# Altium schematic sheet VoltTap.SchDoc(VoltTap)
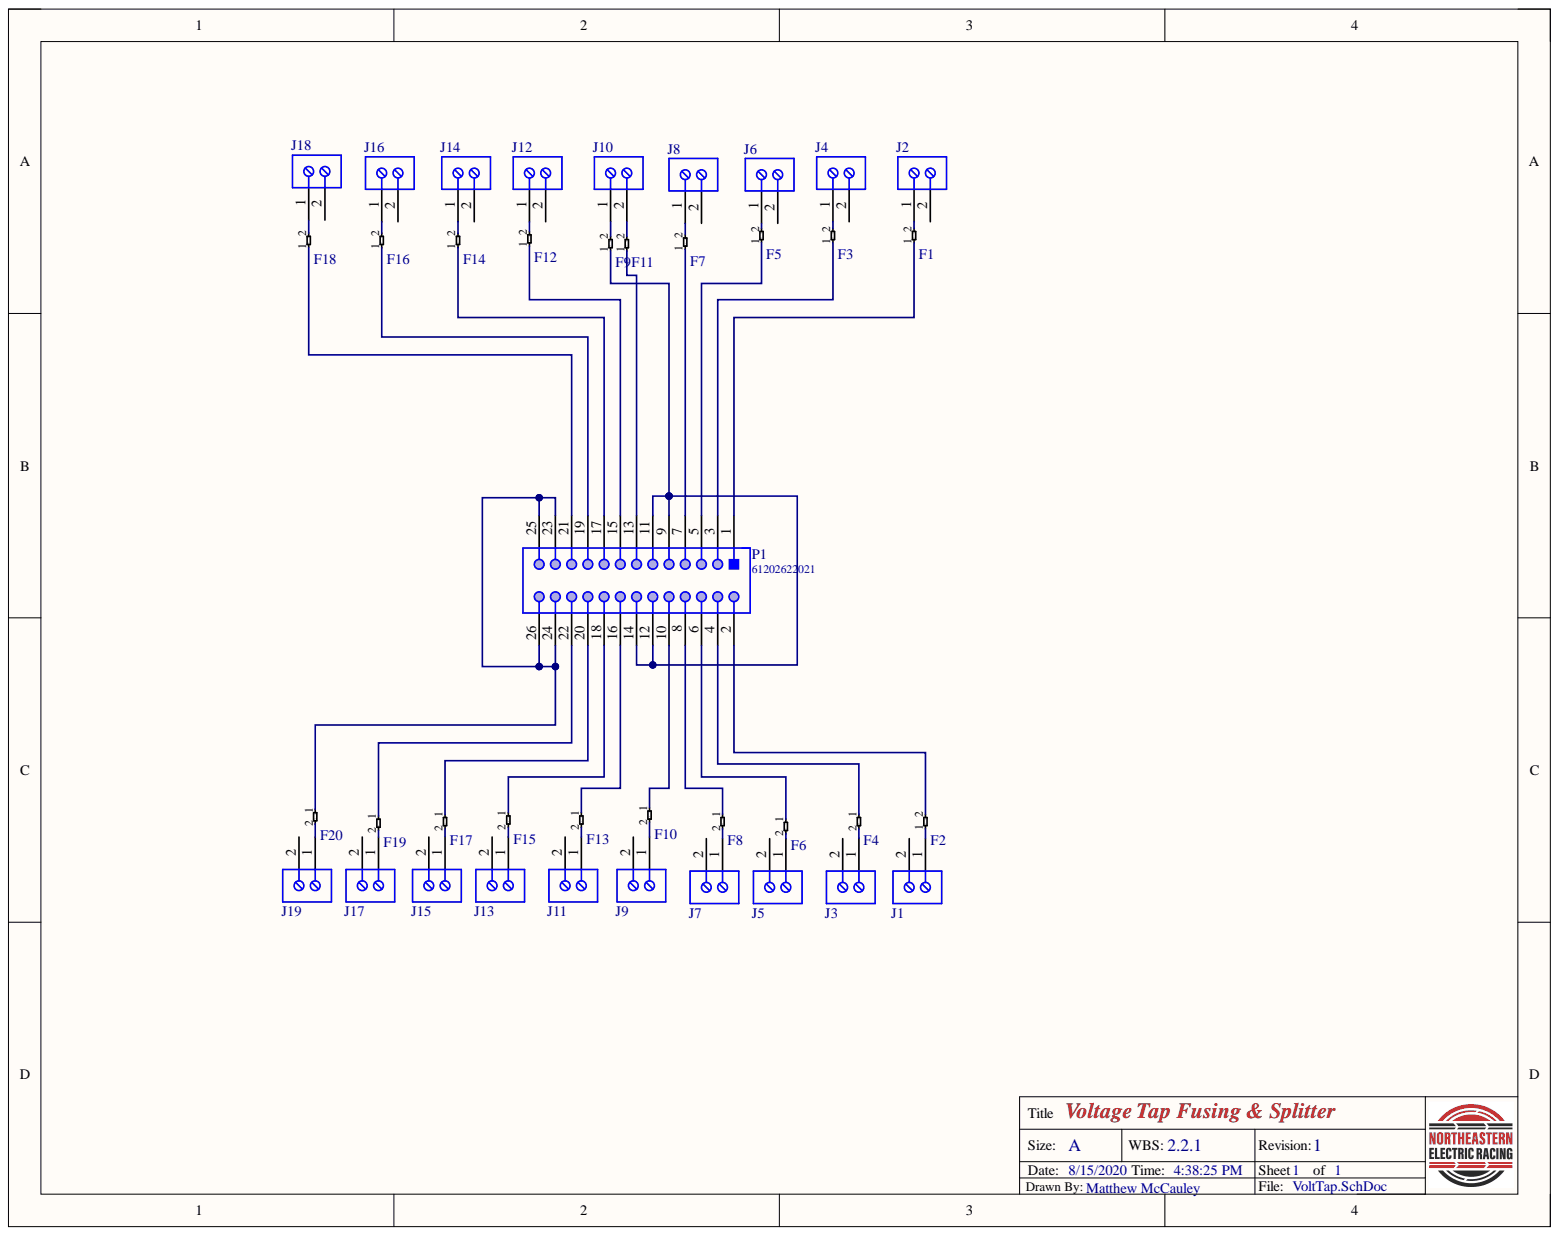
[diagram p0: VoltTap.SchDoc]

## Parts
F1  C1T5  [C1T5]  (value not drawn)
F2  C1T5  [C1T5]  (value not drawn)
F3  C1T5  [C1T5]  (value not drawn)
F4  C1T5  [C1T5]  (value not drawn)
F5  C1T5  [C1T5]  (value not drawn)
F6  C1T5  [C1T5]  (value not drawn)
F7  C1T5  [C1T5]  (value not drawn)
F8  C1T5  [C1T5]  (value not drawn)
F9  C1T5  [C1T5]  (value not drawn)
F10  C1T5  [C1T5]  (value not drawn)
F11  C1T5  [C1T5]  (value not drawn)
F12  C1T5  [C1T5]  (value not drawn)
F13  C1T5  [C1T5]  (value not drawn)
F14  C1T5  [C1T5]  (value not drawn)
F15  C1T5  [C1T5]  (value not drawn)
F16  C1T5  [C1T5]  (value not drawn)
F17  C1T5  [C1T5]  (value not drawn)
F18  C1T5  [C1T5]  (value not drawn)
F19  C1T5  [C1T5]  (value not drawn)
F20  C1T5  [C1T5]  (value not drawn)
J1  691102710002  [691102710002]  (value not drawn)
J2  691102710002  [691102710002]  (value not drawn)
J3  691102710002  [691102710002]  (value not drawn)
J4  691102710002  [691102710002]  (value not drawn)
J5  691102710002  [691102710002]  (value not drawn)
J6  691102710002  [691102710002]  (value not drawn)
J7  691102710002  [691102710002]  (value not drawn)
J8  691102710002  [691102710002]  (value not drawn)
J9  691102710002  [691102710002]  (value not drawn)
J10  691102710002  [691102710002]  (value not drawn)
J11  691102710002  [691102710002]  (value not drawn)
J12  691102710002  [691102710002]  (value not drawn)
J13  691102710002  [691102710002]  (value not drawn)
J14  691102710002  [691102710002]  (value not drawn)
J15  691102710002  [691102710002]  (value not drawn)
J16  691102710002  [691102710002]  (value not drawn)
J17  691102710002  [691102710002]  (value not drawn)
J18  691102710002  [691102710002]  (value not drawn)
J19  691102710002  [691102710002]  (value not drawn)
P1  61202622021  [61202622021]

## Nets
NetF1_1: F1.1 P1.1
NetF1_2: F1.2 J2.1
NetF2_1: F2.1 J1.1
NetF2_2: F2.2 P1.2
NetF3_1: F3.1 P1.3
NetF3_2: F3.2 J4.1
NetF4_1: F4.1 P1.4
NetF4_2: F4.2 J3.1
NetF5_1: F5.1 P1.5
NetF5_2: F5.2 J6.1
NetF6_1: F6.1 P1.6
NetF6_2: F6.2 J5.1
NetF7_1: F7.1 P1.7
NetF7_2: F7.2 J8.1
NetF8_1: F8.1 P1.8
NetF8_2: F8.2 J7.1
NetF9_1: F9.1 P1.9 P1.11 P1.12 P1.14
NetF9_2: F9.2 J10.1
NetF10_1: F10.1 P1.10
NetF10_2: F10.2 J9.1
NetF11_1: F11.1 P1.13
NetF11_2: F11.2 J10.2
NetF12_1: F12.1 P1.15
NetF12_2: F12.2 J12.1
NetF13_1: F13.1 P1.16
NetF13_2: F13.2 J11.1
NetF14_1: F14.1 P1.17
NetF14_2: F14.2 J14.1
NetF15_1: F15.1 P1.18
NetF15_2: F15.2 J13.1
NetF16_1: F16.1 P1.19
NetF16_2: F16.2 J16.1
NetF17_1: F17.1 P1.20
NetF17_2: F17.2 J15.1
NetF18_1: F18.1 P1.21
NetF18_2: F18.2 J18.1
NetF19_1: F19.1 P1.22
NetF19_2: F19.2 J17.1
NetF20_1: F20.1 P1.23 P1.24 P1.25 P1.26
NetF20_2: F20.2 J19.1

Not connected: J1 2; J2 2; J3 2; J4 2; J5 2; J6 2; J7 2; J8 2; J9 2; J11 2; J12 2; J13 2; J14 2; J15 2; J16 2; J17 2; J18 2; J19 2

## Library data of the parts
J1-J19 = CMP-1502-03258-1: Series 102 - 5.00 mm Horizontal Entry Modular with Pressure Clamp WR-TBL, 2 pin; Manufacturer: Wurth Elektronik; Pin Number: 2; Pitch: 5.00mm; Component Kind: Standard; Datasheet URL: http://katalog.we-online.de/em/datasheet/6911027100xx.pdf; Library Name: Altium Content Vault; Manufacturer URL: http://www.we-online.de; Mounting Technology: THT; Operating Temperature: -40to105degC; Package Reference: 691102710002; Packaging: Box; Part Number: 691102710002; Publisher: WurthElektronik
P1 = CMP-1502-00072-1: Male Box Header WR-BHD with lever, THT, Vertical, pitch 2.54 mm, 26 pins; Manufacturer: Wurth Elektronik; Contact Resistance: 20mOhm; Pin Number: 26; Pitch: 2.54mm; Withstanding Voltage: 500VAC; Working Voltage: 250VAC; Component Kind: Standard; Datasheet URL: https://katalog.we-online.de/em/datasheet/6120xx22021.pdf; Gender: Male; Library Name: Altium Content Vault; Manufacturer URL: http://www.we-online.de; Mounting Technology: THT; Operating Temperature: -40to125degC; Orientation: Vertical; Package Reference: 61202622021; Packaging: Tray; Part Number: 61202622021; Published Date: August2018; Publisher: WurthElektronik
F1-F20 = C1T5: Component Kind: Standard; ComponentLink1Description: New link; ComponentLink1URL: https://www.mouser.com/ProductDetail/Bel-Fuse/C1T5?qs=GtFly9OVs8%2FF1GxRAaUoTA%3D%3D#.Xzf8gWXWqWc.link; Library Name: Integrated_Library1.IntLib
